# Altium schematic sheet Sheet1.SchDoc
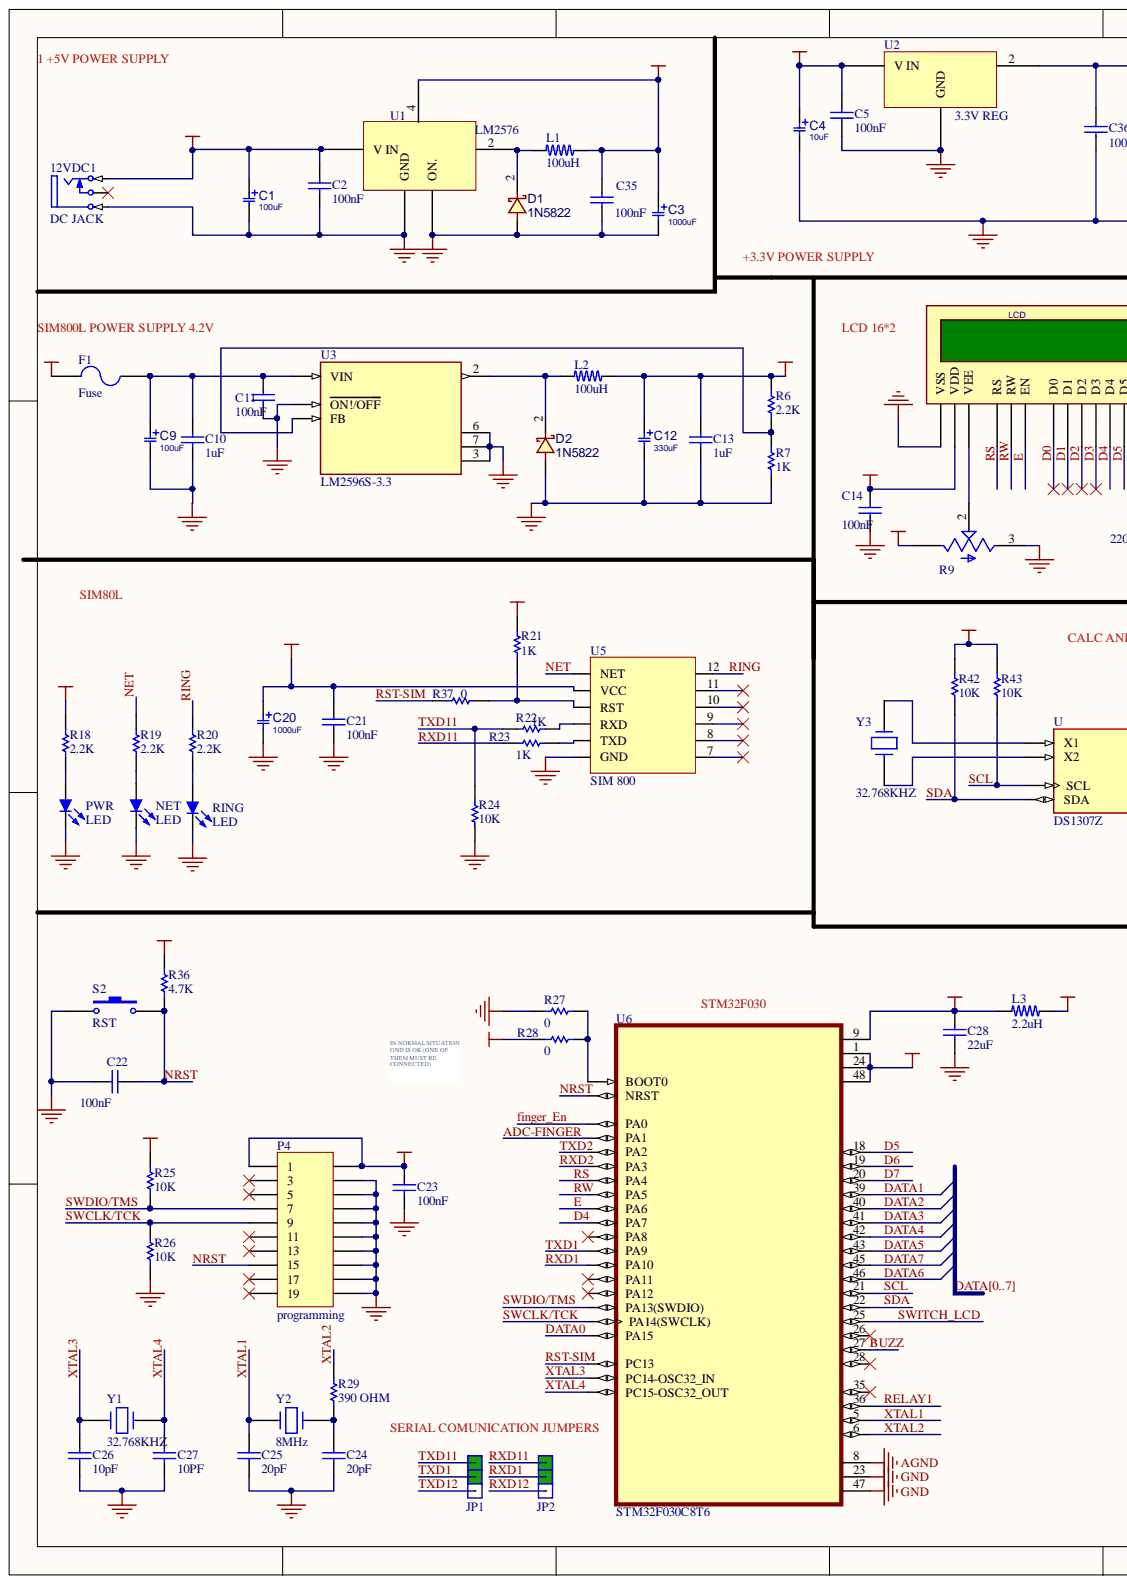
[diagram p0: Sheet1.SchDoc, left]
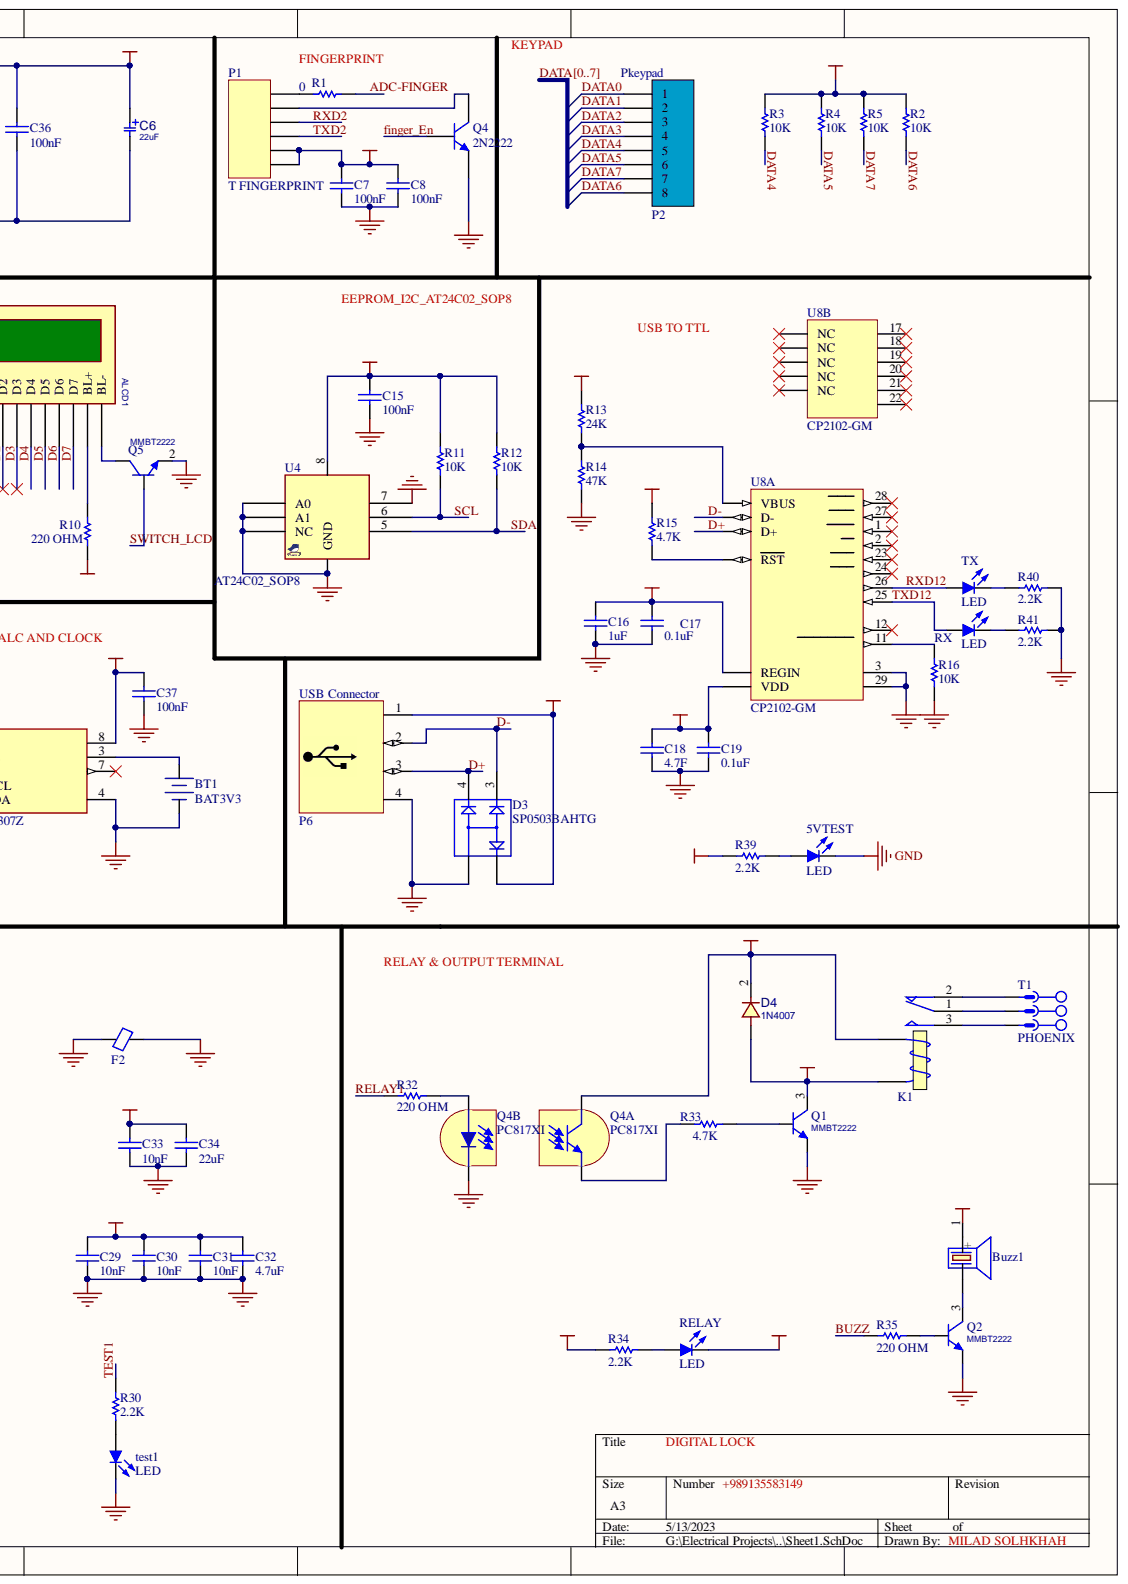
[diagram p1: Sheet1.SchDoc, right]

## Parts
5VTEST  LED  [L0805]
12VDC1  DC JACK  [DC JACK]
ALCD1  LCD  [LCDCHAR_16x2_BLU]
BT1  BAT3V3  [BAT Backup]
Buzz1  (no value)  [BUZZER 7.62mm DC]
C1  100uF  [C_EL_6_8]
C2  100nF  [C 0805]
C3  1000uF  [C_EL_10_10]
C4  10uF  [C_EL_4_5]
C5  100nF  [C 0805]
C6  22uF  [C_EL_5]
C7  100nF  [C 0805]
C8  100nF  [C 0805]
C9  100uF  [C_EL_6_8]
C10  1uF  [C 0805]
C11  100nF  [C 0805]
C12  330uF  [C_EL_8]
C13  1uF  [C 0805]
C14  100nF  [C 0805]
C15  100nF  [C 0805]
C16  1uF  [C 0805]
C17  0.1uF  [C 0805]
C18  4.7F  [C 0805]
C19  0.1uF  [C 0805]
C20  1000uF  [C_EL_10_10]
C21  100nF  [C 0805]
C22  100nF  [C 0805]
C23  100nF  [C 0805]
C24  20pF  [C 0805]
C25  20pF  [C 0805]
C26  10pF  [C 0805]
C27  10PF  [C 0805]
C28  22uF  [C 0805]
C29  10nF  [C 0805]
C30  10nF  [C 0805]
C31  10nF  [C 0805]
C32  4.7uF  [C 0805]
C33  10nF  [C 0805]
C34  22uF  [C 0805]
C35  100nF  [C 0805]
C36  100nF  [C 0805]
C37  100nF  [C 0805]
D1  1N5822  [D_SMA]
D2  1N5822  [D_SMA]
D3  SP0503BAHTG  [SOT143]
D4  1N4007  [D_SMA]
F1  Fuse  [1206 FUSE]
F2  Ferrite Bead SMD  [FB0805]  (value not drawn)
JP1  Jumper  [jumper selector]  (value not drawn)
JP2  Jumper  [jumper selector]  (value not drawn)
K1  Relay  [Relay 18.85x15.35x15.70]  (value not drawn)
L1  100uH  [INDUCTOR SMD 1050]
L2  100uH  [INDUCTOR SMD 1050]
L3  2.2uH  [INDUCTOR SMD 1050]
NET  LED  [L0805]
P1  T FINGERPRINT  [HDR1X6]
P2  Pkeypad  [HDR1X8Male]
P4  programming  [HDR2X10]
P6  USB Connector  [USB2.5-2H4F]
PWR  LED  [L0805]
Q1  MMBT2222  [SOT23_3]
Q2  MMBT2222  [SOT23_3]
Q4  2N2222  [T4-TO92]  (parts A,B)
Q5  MMBT2222  [SOT23_3]
R1  0  [RES 0805]
R2  10K  [RES 0805]
R3  10K  [RES 0805]
R4  10K  [RES 0805]
R5  10K  [RES 0805]
R6  2.2K  [RES 0805]
R7  1K  [RES 0805]
R9  TC33X-2-103E  [TRIM_TC33X-2-103E]  (value not drawn)
R10  220 OHM  [RES 0805]
R11  10K  [RES 0805]
R12  10K  [RES 0805]
R13  24K  [RES 0805]
R14  47K  [RES 0805]
R15  4.7K  [RES 0805]
R16  10K  [RES 0805]
R18  2.2K  [RES 0805]
R19  2.2K  [RES 0805]
R20  2.2K  [RES 0805]
R21  1K  [RES 0805]
R22  1K  [RES 0805]
R23  1K  [RES 0805]
R24  10K  [RES 0805]
R25  10K  [RES 0805]
R26  10K  [RES 0805]
R27  0  [RES 0805]
R28  0  [RES 0805]
R29  390 OHM  [RES 0805]
R30  2.2K  [RES 0805]
R32  220 OHM  [RES 0805]
R33  4.7K  [RES 0805]
R34  2.2K  [RES 0805]
R35  220 OHM  [RES 0805]
R36  4.7K  [RES 0805]
R37  0  [RES 0805]
R39  2.2K  [RES 0805]
R40  2.2K  [RES 0805]
R41  2.2K  [RES 0805]
R42  10K  [RES 0805]
R43  10K  [RES 0805]
RELAY  LED  [L0805]
RING  LED  [L0805]
RX  LED  [L0805]
S2  RST  [Switch - Push to On]
T1  PHOENIX  [MSTB 2,5/3-G]
test1  LED  [L0805]
TX  LED  [L0805]
U  DS1307Z  [SOIC127P600X175-8N]
U1  LM2576  [D2PAK 5PIN]
U2  3.3V REG  [SOT223_N]
U3  LM2596S-3.3  [VREG_LM2596S-3.3]
U4  AT24C02_SOP8  [SO_8]
U5  SIM 800  [SIM800L MODULE]
U6  STM32F030C8T6  [QFP50P900X900X160-48N]
U8  CP2102-GM  [SLAB-QFN-28-3125x3125TP_L]  (parts A,B)
Y1  32.768KHZ  [XTAL 32.768KHz L]
Y2  8MHz  [XTAL]
Y3  32.768KHZ  [XTAL 32.768KHz L]

## Nets
+3.3V: C6.1 C15.2 C23.1 C29.2 C30.2 C31.2 C32.2 C36.2 C37.2 L3.2 P4.1 P4.2 R2.2 R3.2 R4.2 R5.2 R11.2 R12.2 R25.2 R28.2 R36.2 R42.2 R43.2 U.8 U2.2 U4.8 U6.1 U6.24 U6.48
+3.3VA: C28.2 C33.2 C34.2 L3.1 U6.9
+4.2V: C12.1 C13.2 C20.1 C21.2 L2.2 R6.2 R18.2 R21.2 U5.2(VCC)
+5V: ALCD1.2(VDD) Buzz1.1 C3.1 C4.1 C5.2 C7.2 C8.2 C14.1 C35.2 L1.2 P1.1 P1.2 R9.1 R10.1 U1.4 U2.3
+12V: 12VDC1.1 C1.1 C2.2 D4.2 F1.1 K1.4 Q4.4 R34.2 U1.1(V_IN)
AGND: C28.1 F2.1 U6.8
GND: 5VTEST.K 12VDC1.3 ALCD1.1(VSS) BT1.2 C1.2 C2.1 C3.2 C4.2 C5.1 C6.2 C7.1 C8.1 C9.2 C10.1 C11.1 C12.2 C13.1 C14.2 C15.1 C16.1 C17.1 C18.1 C19.1 C20.2 C21.1 C22.2 C23.2 C24.2 C25.2 C26.2 C27.2 C29.1 C30.1 C31.1 C32.1 C33.1 C34.1 C35.1 C36.1 C37.1 D1.1 D2.1 D3.1 F2.2 NET.K P4.4 P4.6 P4.8 P4.10 P4.12 P4.14 P4.16 P4.18 P4.20 P6.4 PWR.K Q1.2 Q2.2 Q4.2 Q4.E Q5.2 R7.1 R9.3 R14.2 R16.1 R24.1 R26.1 R27.2 R40.1 R41.1 RING.K S2.1 test1.K U.4 U1.3(GND) U1.5(ON.) U2.1 U3.3 U3.5(ON!/OFF) U3.6 U3.7 U4.1(A0) U4.2(A1) U4.3(NC) U4.4(GND) U4.7 U5.6(GND) U6.23 U6.47 U8.3 U8.29
RELAYLED: D4.1 K1.5 Q1.3 RELAY.K
VAA-3.3V: C18.2 C19.2 R15.2 U8.6(VDD)
VBUS(+5V): C16.2 C17.2 D3.2 P6.1 R13.1 R39.2 U8.7(REGIN)
ADC-FINGER: R1.1 U6.11(PA1)
BUZZ: R35.2 U6.27
D0: ALCD1.7(D0)
D1: ALCD1.8(D1)
D2: ALCD1.9(D2)
D3: ALCD1.10(D3)
D4: ALCD1.11(D4) U6.17(PA7)
D5: ALCD1.12(D5) U6.18
D6: ALCD1.13(D6) U6.19
D7: ALCD1.14(D7) U6.20
D+: D3.4 P6.3 U8.4(D+)
D-: D3.3 P6.2 U8.5(D-)
DATA0: P2.1 U6.38(PA15)  [also labelled DATA]
DATA1: P2.2 U6.39  [also labelled DATA]
DATA2: P2.3 U6.40  [also labelled DATA]
DATA3: P2.4 U6.41  [also labelled DATA]
DATA4: P2.5 R3.1 U6.42  [also labelled DATA]
DATA5: P2.6 R4.1 U6.43  [also labelled DATA]
DATA6: P2.8 R2.1 U6.46  [also labelled DATA]
DATA7: P2.7 R5.1 U6.45  [also labelled DATA]
E: ALCD1.6(EN) U6.16(PA6)
finger_En: Q4.B U6.10(PA0)
NET: R19.2 U5.1(NET)
NRST: C22.1 P4.15 R36.1 S2.2 U6.7(NRST)
RELAY1: R32.2 U6.36
RING: R20.2 U5.12
RS: ALCD1.4(RS) U6.14(PA4)
RST-SIM: R37.2 U6.2(PC13)
RW: ALCD1.5(RW) U6.15(PA5)
RXD1: JP2.2 U6.31(PA10)
RXD2: P1.4 U6.13(PA3)
RXD11: JP2.1 R23.2
RXD12: JP2.3 TX.A U8.26
SCL: R11.1 R43.1 U.6(SCL) U4.6 U6.21
SDA: R12.1 R42.1 U.5(SDA) U4.5 U6.22
SWCLK/TCK: P4.9 R26.2 U6.37(PA14(SWCLK))
SWDIO/TMS: P4.7 R25.1 U6.34(PA13(SWDIO))
SWITCH_LCD: Q5.1 U6.25
TEST1: R30.2
TXD1: JP1.2 U6.30(PA9)
TXD2: P1.3 U6.12(PA2)
TXD11: JP1.1 R22.2 R24.2
TXD12: JP1.3 RX.A U8.25
XTAL1: C25.1 U6.5 Y2.1
XTAL2: R29.2 U6.6
XTAL3: C26.1 U6.3(PC14-OSC32_IN) Y1.1
XTAL4: C27.1 U6.4(PC15-OSC32_OUT) Y1.2
Net5VTEST_A: 5VTEST.A R39.1
NetALCD1_3: ALCD1.3(VEE) R9.2
NetALCD1_15: ALCD1.15(BL+) R10.2
NetALCD1_16: ALCD1.16(BL-) Q5.3
NetBT1_1: BT1.1 U.3
NetBuzz1_2: Buzz1.2 Q2.3
NetC9_1: C9.1 C10.2 C11.2 F1.2 U3.1(VIN)
NetC24_1: C24.1 R29.1 Y2.2
NetD1_2: D1.2 L1.1 U1.2
NetD2_2: D2.2 L2.1 U3.2
NetK1_1: K1.1 T1.2
NetK1_2: K1.2 T1.1
NetK1_3: K1.3 T1.3
NetNET_A: NET.A R19.1
NetP1_5: P1.5 Q4.C
NetP1_6: P1.6 R1.2
NetPWR_A: PWR.A R18.1
NetQ1_1: Q1.1 R33.1
NetQ2_1: Q2.1 R35.1
NetQ4_1: Q4.1 R32.1
NetQ4_3: Q4.3 R33.2
NetR6_1: R6.1 R7.2 U3.4(FB)
NetR13_2: R13.2 R14.1 U8.8(VBUS)
NetR15_1: R15.1 U8.9(RST)
NetR16_2: R16.2 U8.11
NetR20_1: R20.1 RING.A
NetR21_1: R21.1 R37.1 U5.3(RST)
NetR22_1: R22.1 U5.4(RXD)
NetR23_1: R23.1 U5.5(TXD)
NetR27_1: R27.1 R28.1 U6.44(BOOT0)
NetR30_1: R30.1 test1.A
NetR34_1: R34.1 RELAY.A
NetR40_2: R40.2 TX.K
NetR41_2: R41.2 RX.K
NetU_1: U.1(X1) Y3.2
NetU_2: U.2(X2) Y3.1

Not connected: 12VDC1 2; P4 3 5 11 13 17 19; U 7; U5 7 8 9 10 11; U6 26 28 29(PA8) 32(PA11) 33(PA12) 35; U8 1 2 10(NC) 12 13(NC) 14(NC) 15(NC) 16(NC) 17 18 19 20 21 22 23 24 27 28

## Library data of the parts
12VDC1 = DC JACK: CONNECTOR; Component Kind: Standard; Library Name: FINAL_Project.SCHLIB
ALCD1 = LCD: Alphanumic LCD; Designer: Abbas Basereh; Component Kind: Standard; Data: 16-12-2016; Library Name: FINAL_Project.SCHLIB
BT1 = Battry Backup: Designer: Abbas Basereh; Component Kind: Standard; Data: 16-12-2016; Description: Battry Backup; Library Name: FINAL_Project.SCHLIB
Buzz1 = PIEZO BUZZER: PIEZO BUZZER ACTIVE; Comment_1: SPEAKER AND BEEP; Designer: Abbas Basereh; Component Kind: Standard; ComponentLink2Description: Reference; Design Date: 1395/11/14; Mounting Technology: THD; PackageDescription: THD; Pin Count: 2; Status: Unreleased; Library Name: Fingerprint_Password_Security_1.SCHLIB; Publisher: Abbas Basereh
C1 C4 C9 = CAP_SMD_EL: CAP, THD, ELEC,; DESC: DESCRIPTION; DIST#: MOUSER; MANF_P#: MANF_PART#; PARAM: 25V; PARAM2: 20%; : http://www.chila.ir; ASSEMBLY: ASSEMBLY; Component Kind: Standard; Library Name: PASSIVE.IntLib; MANF: MANF; PRICE: 10= 5$
  C1 C9: VALUE: 100uF
  C4: VALUE: 10uF
C2 C5 C7 C8 C10 C11 C13-C19 C21-C37 = CAP CERAIC: Capacitor; Component Kind: Standard; Library Name: FINAL_Project.SCHLIB
  C2 C5 C7 C8 C11 C14 C15 C21-C23 C35-C37: VALUE: 100nF
  C10 C13 C16: VALUE: 1uF
  C17 C19: VALUE: 0.1uF
  C18: VALUE: 4.7F
  C24 C25: VALUE: 20pF
  C26: VALUE: 10pF
  C27: VALUE: 10PF
  C28 C34: VALUE: 22uF
  C29-C31 C33: VALUE: 10nF
  C32: VALUE: 4.7uF
C3 C20 = CAP_SMD_EL: CAP, THD, ELEC,; DESC: DESCRIPTION; DIST#: MOUSER; MANF_P#: MANF_PART#; PARAM: 10V; PARAM2: 20%; : http://www.chila.ir; ASSEMBLY: ASSEMBLY; Component Kind: Standard; Library Name: PASSIVE.IntLib; MANF: MANF; PRICE: 10= 5$; VALUE: 1000uF
C6 C12 = CAP_SMD_EL: CAP, THD, ELEC,; DESC: DESCRIPTION; DIST#: MOUSER; MANF_P#: MANF_PART#; PARAM: 16V; PARAM2: 20%; : http://www.chila.ir; ASSEMBLY: ASSEMBLY; Component Kind: Standard; Library Name: PASSIVE.IntLib; MANF: MANF; PRICE: 10= 5$
  C6: VALUE: 22uF
  C12: VALUE: 330uF
D1 D2 = DIODE_SMD_SCHOTTKY_1N5822: DIODE, SCHOTTKY, 40V, 3A; DESC: DESCRIPTION; MANF: DIODES; : http://www.chila.ir; ASSEMBLY: ASSEMBLY; Component Kind: Standard; Library Name: diode.SchLib; MANF_P#: 1N5822; PARAM: 40V; PARAM2: 3A; PRICE: PRICE; VALUE: 1N5822
D3 = SP0503BAHTG: 8.5V Clamp Ipp Tvs Diode Surface Mount SOT-143-4; MF: Littelfuse Inc.; Component Kind: Standard; Digi-Key_Part_Number: F2715CT-ND; Digi-Key_Purchase_URL: https://www.digikey.com/product-detail/en/littelfuse-inc/SP0503BAHTG/F2715CT-ND/1154322?utm_source=snapeda&utm_medium=aggregator&utm_campaign=symbol; Library Name: SP0503BAHTG.IntLib; MP: SP0503BAHTG; Package: SOT-143 Littelfuse; SnapEDA_Link: https://www.snapeda.com/parts/SP0503BAHTG/Littelfuse%20Inc./view-part/214427/?ref=snap
D4 = DIODE_SMD_1N4007: DIODE, GENERAL PURPOSE, 1000V/1A; DESC: DESCRIPTION; MANF: Kingtronics; MANF_P#: M7; PARAM: 1000V VRRM; PARAM2: 1A; : http://www.chila.ir; ASSEMBLY: ASSEMBLY; Component Kind: Standard; Library Name: diode.SchLib; PRICE: PRICE; VALUE: 1N4007
F1 = Fuse 2: Component Kind: Standard; Description: Fuse; LatestRevisionDate: 17-Jul-2002; LatestRevisionNote: Re-released for DXP Platform.; Library Name: FINAL_Project.SCHLIB; PackageDescription: Thru-Hole; 2 Leads; PackageReference: PIN-W2/E2.8; Published: 8-Jun-2000; Simulation: FUSE; Publisher: Altium Limited
F2 = Ferrite Bead SMD Package: Designer: Ali Hafizi; Component Kind: Standard; Description: Ferrite Bead SMD; Library Name: FINAL_Project.SCHLIB
JP1 JP2 = Jumper Selector: Component Kind: Standard; Description: Jumper Selector; Library Name: FINAL_Project.SCHLIB
K1 = Relay: SPDT Relay; Company: E.P.I.L; Designer: Eng. Saeid Jorablo; Component Kind: Standard; Design_Date: 2011/10/31; Library Name: Fingerprint_Password_Security_1.SCHLIB
L1-L3 = Inductor: Component Kind: Standard; Description: INDUCTOR; Library Name: iDr.SIM800L Module Supply.IntLib; Published: 8-Jun-2000; Revision: July-2002: Re-released for DXP Platform.; Signal Integrity: Inductor; Simulation: INDUCTOR; Publisher: Altium Limited
  L1 L2: Value: 100uH
  L3: Value: 2.2uH
P1 = Header 6: Header, 6-Pin; Component Kind: Standard; LatestRevisionDate: 17-Jul-2002; LatestRevisionNote: Re-released for DXP Platform.; Library Name: Miscellaneous Connectors.IntLib; Signal Integrity: Connector; Publisher: Altium Limited
P2 = Header 8: Header, 7-Pin; Component Kind: Standard; Library Name: Fingerprint_Password_Security.SCHLIB
P4 = Header 10X2: Header, 10-Pin, Dual row; Component Kind: Standard; LatestRevisionDate: 17-Jul-2002; LatestRevisionNote: Re-released for DXP Platform.; Library Name: Miscellaneous Connectors.IntLib; Signal Integrity: Connector; Publisher: Altium Limited
P6 = USB B-Type: USB Connector-B Type; Adding Date: 10/26/2010; Component Kind: Standard; Library Name: Altium.IntLib
Q1 Q2 Q5 = NPN_MMBT2222A_SOT23: BJT, NPN, 40v, 0.6A, SMD, SOT23; MANF: Diodes, NXP, FAIRCHILD, ON; PARAM: hFE100~300; PARAM2: 0.6A, 40v, 250mW; : http://www.chila.ir; Component Kind: Standard; Library Name: transistor_bjt.SchLib; MANF_P#: MMBT2222A; Simulation: NS3904; VALUE: MMBT2222
Q4 = 2N2222: NPN; De: Seyed Mohsen Ghasemian; E-mail: picpars@gmail.com; Component Kind: Standard; Library Name: FINAL_Project.SCHLIB; web: www.picpars.com
R1-R7 R10-R16 R18-R30 R32-R37 R39-R43 = RES 0805 0.125W: RES 0805; Desc.: RES SMD 0805; Designer: Abbas Basereh; Component Kind: Standard; Data: 16-12-2016; Library Name: FINAL_Project.SCHLIB
  R1 R27 R28 R37: VALUE: 0
  R2-R5 R11 R12 R16 R24-R26 R42 R43: VALUE: 10K
  R6 R18-R20 R30 R34 R39-R41: VALUE: 2.2K
  R7 R21-R23: VALUE: 1K
  R10 R32 R35: VALUE: 220 OHM
  R13: VALUE: 24K
  R14: VALUE: 47K
  R15 R33 R36: VALUE: 4.7K
  R29: VALUE: 390 OHM
R9 = TC33X-2-103E: 10 kOhms 0.1W, 1/10W J Lead Surface Mount Trimmer Potentiometer Cermet 1 Turn Top Adjustment; MF: Bourns; Check_prices: https://www.snapeda.com/parts/TC33X-2-103E/Bourns/view-part/47092/?ref=eda; Component Kind: Standard; Digi-Key_Part_Number: TC33X-103ECT-ND; Library Name: FINAL_Project.SCHLIB; MP: TC33X-2-103E; Package: SOD-23 Bourns; Purchase-URL: https://pricing.snapeda.com/search/part/TC33X-2-103E/?ref=eda; SnapEDA_Link: https://www.snapeda.com/parts/TC33X-2-103E/Bourns/view-part/47092/?ref=snap
S2 = SW-PB: Switch; Component Kind: Standard; LatestRevisionDate: 17-Jul-2002; LatestRevisionNote: Re-released for DXP Platform.; Library Name: FINAL_Project.SCHLIB; PackageDescription: Switch; 2 Leads; PackageReference: SPST-2; Published: 24-Mar-1999; Publisher: Altium Limited
T1 = CON_Phoenix_MSTBV_03: 3 Pitch: 5 mm Color: green Contact surface: Tin Assembly; Manufacturer: PHOENIX CONTACT; Connector type: PCB Terminal Block; designer: ABBAS BASEREH; Max Current: 12 A; Positions: 3; Voltage: 320 V; Category: Connector; Component Kind: Standard; ComponentLink1Description: Header, Nominal current: 12 A Rated voltage (III/2): 320 V Number of positions: 3 Pitch: 5 mm Color: green Contact surface: Tin Assembly: Soldering  DESIGNER:ABBAS BASEREH; ComponentLink2Description: Reference; Library Name: FINAL_Project.SCHLIB; Mounting Technology: Through-Hole; PART.NO: PHOENIX; Pin Count: 3; Revision 1: 2017-3-1; Signal Integrity: CON-3P; Status: Unreleased
U = DS1307Z: Real Time Clock _RTC_ IC Clock/Calendar 56B IÂ²C, 2-Wire Serial 8-SOIC _0.154, 3.90mm Width_; MF: Maxim Integrated; Availability: Unavailable; Component Kind: Standard; Library Name: DS1307Z.IntLib; MP: DS1307Z; Package: SO-8 Maxim Integrated; Price: None; SnapEDA_Link: https://www.snapeda.com/parts/DS1307Z/Maxim%20Integrated/view-part/524266/?ref=snap
U1 = LM25XX: VOLTAGE REGULATOR; Component Kind: Standard; Library Name: FINAL_Project.SCHLIB
U2 = AMS 1117: VOLTAGE REGULATOR; Component Kind: Standard; Library Name: Fingerprint_Password_Security_1.SCHLIB
U3 = LM2596S-3.3: Buck Switching Regulator IC Positive Fixed 3.3V 1 Output 3A TO-263-6, DÂ²Pak _5 Leads + Tab_, TO-263BA; MF: Texas Instruments; Availability: Unavailable; Component Kind: Standard; Library Name: LM2596S-3.3.IntLib; MP: LM2596S-3.3; Package: TO-263-6 HTC Korea; Price: None; SnapEDA_Link: https://www.snapeda.com/parts/LM2596S-3.3/Texas%20Instruments/view-part/2769910/?ref=snap
U4 = EEPROM_I2C_AT24C02_SOP8: EEPROM, I2C; : http://www.chila.ir; Component Kind: Standard; Library Name: memory_eeprom_I2C.SchLib; VALUE: AT24C02
U5 = SIM 800L: Component Kind: Standard; Description: SIM 800; Library Name: iDr.SIM800L Module Supply.IntLib
U6 = STM32F030C8T6: STM32F Series 32-bit 64 kB Flash 8 kB RAM ARM Based Microcontroller - LQFP-48; Availability: Unavailable; Component Kind: Standard; Library Name: STM32F030C8T6.IntLib; MP: STM32F030C8T6; Package: None; Price: None
U8 = CP2102-GM: Single-Chip USB to UART Bridge, 1024 Bytes EEPROM, -40 to 85 degC, 28-pin QFN, Tube; Manufacturer: Silicon Labs; Internal Oscillator: TRUE; Sub-Family: USB Bridges; Code_IPC: J-STD-020C; Code_JEDEC: MO-220; Component Kind: Standard; ComponentLink1Description: Manufacturer URL; ComponentLink1URL: http://www.silabs.com/; ComponentLink2Description: Datasheet; ComponentLink2URL: http://www.silabs.com/Support%20Documents/TechnicalDocs/CP2102-9.pdf; ComponentLink3Description: Package Specification; ComponentLink3URL: http://www.silabs.com/Support%20Documents/TechnicalDocs/C8051T61x.pdf; DatasheetVersion: Rev. 1.5; EEPROM: 1024 Bytes; Library Name: Silicon_Labs_CP2102_GM.SchLib; Mounting Technology: Surface Mount; PackageDescription: 28-Pin Quad-Flat No-Leads Package, Body 5 x 5 mm, Pitch 0.5 mm; PackageReference: QFN-28; PartNumber: CP2102-GM
Y1-Y3 = XTAL: Crystal; Component Kind: Standard; Library Name: FINAL_Project.SCHLIB
  Y1 Y3: VALUE: 32.768KHZ
  Y2: VALUE: 8MHZ
5VTEST NET PWR RELAY RING RX test1 TX = LED: Component Kind: Standard; Description: LED
  5VTEST RELAY RX TX: Library Name: FINAL_Project.SCHLIB
  NET PWR RING test1: Library Name: iDr.SIM800L Module Supply.IntLib
